# Altium schematic sheet Hillstar I2C-USB Bridge.SchDoc
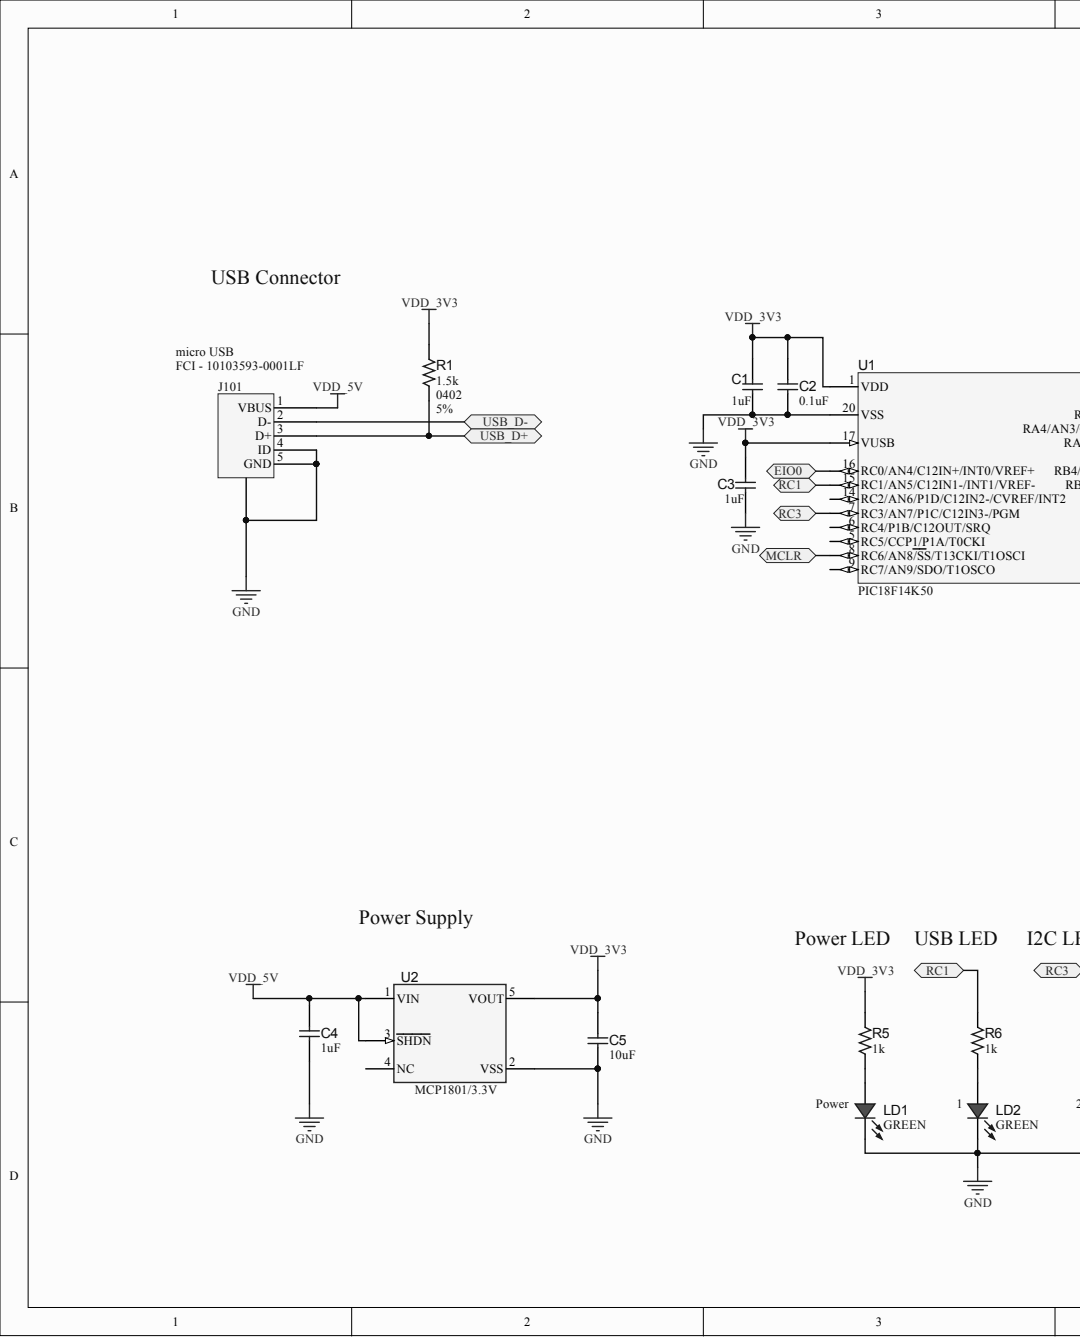
[diagram p0: Hillstar I2C-USB Bridge.SchDoc, left]
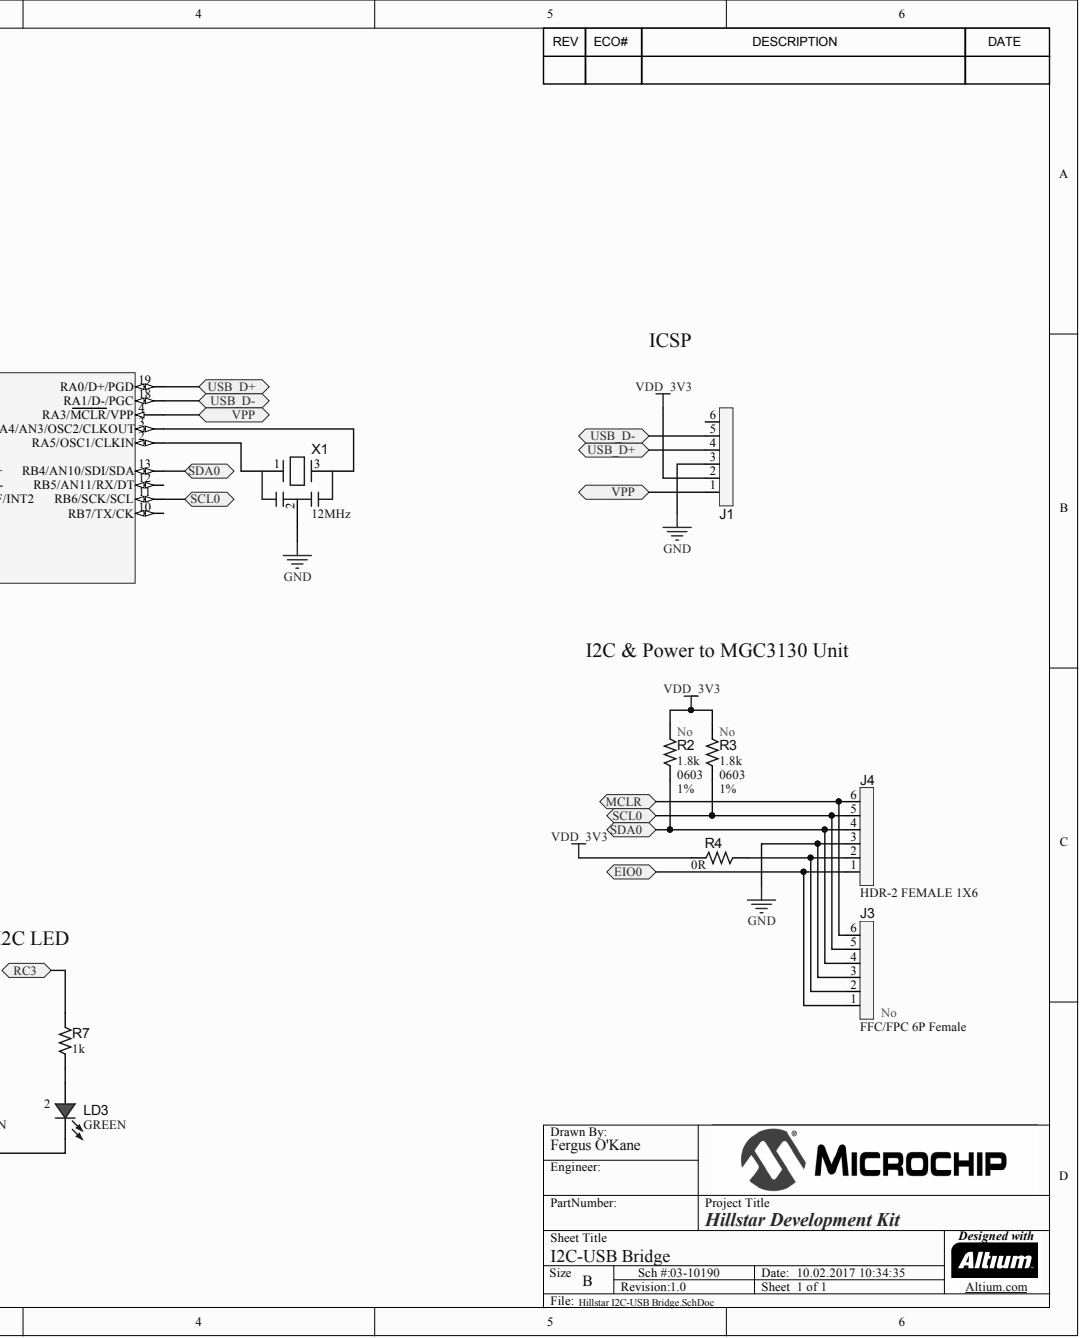
[diagram p1: Hillstar I2C-USB Bridge.SchDoc, right]

## Parts
C1  value 1uF  [CAP_C_0402]  (hidden comment: CAP CER 1uF 6.3V 10% X5R SMD 0402)
C2  value 0.1uF  [CAP_C_0402]  (hidden comment: CAP CER 0.1uF 10V 10% X5R SMD 0402)
C3  value 1uF  [CAP_C_0402]  (hidden comment: CAP CER 1uF 6.3V 10% X5R SMD 0402)
C4  value 1uF  [CAP_C_0402]  (hidden comment: CAP CER 1uF 6.3V 10% X5R SMD 0402)
C5  value 10uF  [CAP_C_0603]  (hidden comment: CAP CER 10uF 10V 20% X5R SMD 0603)
J1  Header 1x6  [HDR_M_1.27_1x6SMD]  (value not drawn)
J3  value FFC/FPC 6P Female  Populated: No  Type: FFC/FPC  [CON_FFC_1.0_FEMALE_1X6_SMD_52207]  (hidden comment: Header 1x6)
J4  value HDR-2 FEMALE 1X6  [HDR_F_2_1X6_SMD_RA]  (hidden comment: Header 1x6)
J101  micro USB  value FCI - 10103593-0001LF  Manufacturer 1: FCI  Manufacturer Part Number 1: 10103593-0001LF  [FCI - 10103593-0001LF]
LD1  value GREEN  [LED_0603_GREEN]  (hidden comment: LTST-C191KGKT)
LD2  value GREEN  [LED_0603_GREEN]  (hidden comment: LTST-C191KGKT)
LD3  value GREEN  [LED_0603_GREEN]  (hidden comment: LTST-C191KGKT)
R1  value 1.5k  Package: 0402  Tolerance: 5%  [RES_C_0402]  (hidden comment: RES TKF 1.5k 5% 1/16W SMD 0402)
R2  value 1.8k  Package: 0603  Populated: No  Tolerance: 1%  [RES_C_0603]  (hidden comment: RES TKF 1.8k 1% 1/10W SMD 0603)
R3  value 1.8k  Package: 0603  Populated: No  Tolerance: 1%  [RES_C_0603]  (hidden comment: RES TKF 1.8k 1% 1/10W SMD 0603)
R4  value 0R  [RES_C_0603]  (hidden comment: RES TKF 0R 1/10W SMD 0603)
R5  value 1k  [RES_C_0402]  (hidden comment: RES TKF 1k 5% 1/16W SMD 0402)
R6  value 1k  [RES_C_0402]  (hidden comment: RES TKF 1k 5% 1/16W SMD 0402)
R7  value 1k  [RES_C_0402]  (hidden comment: RES TKF 1k 5% 1/16W SMD 0402)
U1  value PIC18F14K50  [SSOP-20_P0.65]  (hidden comment: PIC18F14K50T-I/SS)
U2  value MCP1801/3.3V  [SOT-23-5]  (hidden comment: MCP1801 SOT-23-5)
X1  value 12MHz  [RESONATOR_CSTCE-G_L3.2W1.3H0.8]  (hidden comment: CSTCE12M0G55Z-R0)

## Ports
EIO0, MCLR, RC1, RC3, SCL0, SDA0, USB_D+, USB_D-, VPP

## Nets
GND: C1.1 C2.1 C3.1 C4.1 C5.1 J1.3 J3.3 J4.3 J101.4(ID) J101.5(GND) J101.GND LD1.2 LD2.2 LD3.2 U1.20(VSS) U2.2(VSS) X1.2
VDD_3V3: C1.2 C2.2 C3.2 C5.2 J1.2 R1.2 R2.2 R3.2 R4.1 R5.2 U1.1(VDD) U1.17(VUSB) U2.5(VOUT)
VDD_5V: C4.2 J101.1(VBUS) U2.1(VIN) U2.3(SHDN)
EIO0: J3.1 J4.1 U1.16(RC0/AN4/C12IN+/INT0/VREF+) ; port EIO0
MCLR: J3.6 J4.6 U1.8(RC6/AN8/SS/T13CKI/T1OSCI) ; port MCLR
RC1: R6.2 U1.15(RC1/AN5/C12IN1-/INT1/VREF-) ; port RC1
RC3: R7.2 U1.7(RC3/AN7/P1C/C12IN3-/PGM) ; port RC3
SCL0: J3.5 J4.5 R3.1 U1.11(RB6/SCK/SCL) ; port SCL0
SDA0: J3.4 J4.4 R2.1 U1.13(RB4/AN10/SDI/SDA) ; port SDA0
VPP: J1.1 U1.4(RA3/MCLR/VPP) ; port VPP
NetJ1_4: J1.4 J101.3(D+) R1.1 U1.19(RA0/D+/PGD)
NetJ1_5: J1.5 J101.2(D-) U1.18(RA1/D-/PGC)
NetJ3_2: J3.2 J4.2 R4.2
NetLD1_1: LD1.1 R5.1
NetLD2_1: LD2.1 R6.1
NetLD3_1: LD3.1 R7.1
NetU1_2: U1.2(RA5/OSC1/CLKIN) X1.1
NetU1_3: U1.3(RA4/AN3/OSC2/CLKOUT) X1.3

Not connected: J1 6; U1 5(RC5/CCP1/P1A/T0CKI) 6(RC4/P1B/C12OUT/SRQ) 9(RC7/AN9/SDO/T1OSCO) 10(RB7/TX/CK) 12(RB5/AN11/RX/DT) 14(RC2/AN6/P1D/C12IN2-/CVREF/INT2); U2 4(NC)

## Library data of the parts
C1 C3 C4 = CAP0616: Capacitor; Manufacturer 1: Murata; Manufacturer Part Number 1: GRM155R60J105KE19D; Populated: Yes; Type: Ceramic; Category: Capacitor; Component Kind: Standard; ComponentLink1Description: Datasheet; ComponentLink1URL: https://chn-vm-apps.microchip.com/mchprepo/parts/Datasheets/L0005E.pdf; Database Table Name: Capacitor; Description_: CAP CER 1uF 6.3V 10% X5R SMD 0402; Library Name: MCHP-CDB-Offline.DbLib; MCHP-ID: CAP0616; Operating Temperature: -55C to +85C; Package: 0402; Quantity Override: 1; Revision: 1; Status: Production; Supplier 1: Digi-Key; Supplier Part Number 1: 490-1320-1-ND; Temperature Coeffcient: X5R; Tolerance: 10%; Voltage: 6.3V
C2 = CAP0279: Capacitor; Manufacturer 1: KEMET; Manufacturer Part Number 1: C0402C104K8PACTU; Populated: Yes; Type: Ceramic; Category: Capacitor; Component Kind: Standard; ComponentLink1Description: Datasheet; ComponentLink1URL: https://chn-vm-apps.microchip.com/mchprepo/parts/Datasheets/KEM_C1002_X7R_SMD.pdf; Database Table Name: Capacitor; Description_: CAP CER 0.1uF 10V 10% X5R SMD 0402; Library Name: MCHP-CDB-Offline.DbLib; Manufacturer 2: Murata; Manufacturer 3: KEMET; Manufacturer Part Number 2: GRM155R61A104KA01D; Manufacturer Part Number 3: C0402C104K8PAC; MCHP-ID: CAP0279; Operating Temperature: -55C to +85C; Package: 0402; Quantity Override: 1; Revision: 1; Status: Production; Supplier 1: Digi-Key; Supplier 2: Digi-Key; Supplier 3: KEMET; Supplier Part Number 1: 399-3027-1-ND; Supplier Part Number 2: 490-1318-2-ND; Supplier Part Number 3: C0402C104K8PAC; Temperature Coeffcient: X5R; Tolerance: 10%; Voltage: 10V
C5 = CAP0542: Capacitor; Manufacturer 1: Panasonic; Manufacturer Part Number 1: ECJ-1VB1A106M; Populated: Yes; Type: Ceramic; Category: Capacitor; Component Kind: Standard; ComponentLink1Description: Datasheet; ComponentLink1URL: https://chn-vm-apps.microchip.com/mchprepo/parts/Datasheets/ECJ__General_.pdf; Database Table Name: Capacitor; Description_: CAP CER 10uF 10V 20% X5R SMD 0603; Library Name: MCHP-CDB-Offline.DbLib; Manufacturer 2: TDK Corporation; Manufacturer Part Number 2: C1608X5R1A106M; MCHP-ID: CAP0542; Operating Temperature: -55C to +85C; Package: 0603; Quantity Override: 1; Revision: 2; Status: Production; Supplier 1: Digi-Key; Supplier 2: Digi-Key; Supplier Part Number 1: PCC2479CT-ND; Supplier Part Number 2: 445-6853-1-ND; Temperature Coeffcient: X5R; Tolerance: 20%; Voltage: 10V
J1 = Header 1x6: Header 6-pin SMD; Component Kind: Standard; Library Name: Header6p_SMD_127.IntLib
J3 = CON1291: Manufacturer 1: Molex; Manufacturer Part Number 1: 52207-0633; Database Table Name: Connector; Description_: CON FFC/FPC 52207 1mm 6P Female SMD R/A; Owner: Tad Ahlvers; Category: Connector; Component Kind: Standard; ComponentLink1Description: Datasheet; ComponentLink1URL: https://chn-vm-apps.microchip.com/mchprepo/parts/Datasheets/0522070633_FFC_FPC_CONNECTORS-295382.pdf; Library Name: MCHP-CDB.DbLib; Manufacturer 2: Molex; Manufacturer Part Number 2: 0522070685; MCHP-ID: CON1291; Quantity Override: 1; Revision: B; Status: Design; Supplier 1: Mouser; Supplier 2: Digi-Key; Supplier Part Number 1: 538-52207-0633; Supplier Part Number 2: WM7676CT-ND
J4 = CON1205: Manufacturer 1: Fischer Elektronik; Manufacturer Part Number 1: BLY 6 SMD 6; Current: 2.5A; Database Table Name: Connector; Description_: CON HDR-2 Female 1x6 Tin SMD R/A; Owner: Jairuz Agang-ang; Populated: YES; Value: HDR-2 FEMALE 1X6; Voltage: 100 V DC; Category: Connector; Component Kind: Standard; ComponentLink1Description: Datasheet; ComponentLink1URL: https://chn-vm-apps.microchip.com/mchprepo/parts/Datasheets/735584-da-01-de-BUCHSENLEISTE__BLY_6_SMD__20.pdf; Library Name: MCHP-CDB-Offline.DbLib; MCHP-ID: CON1205; Quantity Override: 1; Revision: 1; Status: Production; Supplier 1: Fischer Elektronik; Supplier Part Number 1: BLY 6 SMD 6; Type: Header 2mm
J101 = micro USB: Description_: USB - micro B 2.0 5 Position Board Cutout; Populated: Yes; Component Kind: Standard; Library Name: micro_USB.SchLib; Supplier 1: Digi-Key; Supplier Part Number 1: 609-4049-1-ND
LD1-LD3 = DIODE1155: LED; Manufacturer 1: Lite-On Inc; Database Table Name: Diode; Description_: DIO LED GREEN 2V 30mA 35mcd Clear SMD 0603; Forward Current: 30mA; Owner: Tad Ahlvers; Populated: YES; Power: 75mW; Reverse Voltage: 5V; Type: LED Single; Category: Diode; Component Kind: Standard; ComponentLink1Description: Datasheet; ComponentLink1URL: https://chn-vm-apps.microchip.com/mchprepo/parts/Datasheets/S_110_LTST-C191KGKT.pdf; Library Name: MCHP-CDB.DbLib; Manufacturer Part Number 1: LTST-C191KGKT; MCHP-ID: DIODE1155; Operating Temperature: -55C to +85C; Quantity Override: 1; Revision: B; Status: Design; Supplier 1: Digi-Key; Supplier Part Number 1: 160-1446-1-ND
R1 = RES1493: Resistor; Manufacturer 1: Rohm Semiconductor; Manufacturer Part Number 1: MCR01MRTJ152; Owner: Mike Ragsdale; Populated: YES; Voltage: 50V; Category: Resistor; Component Kind: Standard; ComponentLink1Description: Datasheet; ComponentLink1URL: https://chn-vm-apps.microchip.com/mchprepo/parts/Datasheets/mcr_g.pdf; Composition: TKF; Database Table Name: Resistor; Description_: RES TKF 1.5k 5% 1/16W SMD 0402; Library Name: MCHP-CDB-Offline.DbLib; MCHP-ID: RES1493; Operating Temperature: -55C to +155C; Power: 1/16W; Quantity Override: 1; Revision: 1; Status: Design; Supplier 1: Digi-Key; Supplier Part Number 1: RHM1.5KCETR-ND; Type: RES SMD
R2 R3 = RES1110: Resistor; Manufacturer 1: Panasonic; Manufacturer Part Number 1: ERJ-3EKF1801V; Owner: Bogdan P (M91398); Temperature Coeffcient: 100ppm/C; Voltage: 75V; Category: Resistor; Component Kind: Standard; ComponentLink1Description: Datasheet; ComponentLink1URL: https://chn-vm-apps.microchip.com/mchprepo/parts/Datasheets/AOA0000CE2.pdf; Composition: TKF; Database Table Name: Resistor; Description_: RES TKF 1.8k 1% 1/10W SMD 0603; Library Name: MCHP-CDB-Offline.DbLib; MCHP-ID: RES1110; Operating Temperature: -55C to +155C; Power: 1/10W; Quantity Override: 1; Revision: 0; Status: Production; Supplier 1: Digi-Key; Supplier Part Number 1: P1.80KHCT-ND; Type: RES SMD
R4 = RSMT0001: Resistor; Manufacturer 1: NIC Components; Manufacturer Part Number 1: NRC06Z0TRF; Populated: Yes; Category: Resistor; Component Kind: Standard; ComponentLink1Description: Datasheet; ComponentLink1URL: https://chn-vm-apps.microchip.com/mchprepo/parts/Datasheets/nrc.pdf; Composition: TKF; Database Table Name: Resistor; Description_: RES TKF 0R 1/10W SMD 0603; Library Name: MCHP-CDB-Offline.DbLib; Manufacturer 2: Panasonic; Manufacturer 3: Panasonic; Manufacturer 4: Stackpole Electronics Inc; Manufacturer 5: Vishay; Manufacturer 6: Stackpole Electronics Inc; Manufacturer 7: Yageo; Manufacturer 8: KOA Speer; Manufacturer 9: ROHM; Manufacturer Part Number 2: ERJ-3GSY0R00V; Manufacturer Part Number 3: ERJ-3GEY0R00V; Manufacturer Part Number 4: RMCF0603ZT0R00; Manufacturer Part Number 5: CRCW06030000Z0EA; Manufacturer Part Number 6: RMCF 1/16 0 R; Manufacturer Part Number 7: RC0603JR-070RL; Manufacturer Part Number 8: RK73Z1JTTP; Manufacturer Part Number 9: MCR03EZPJ000; MCHP-ID: RSMT0001; Operating Temperature: -55C to +155C; Package: 0603; Power: 1/10W; Quantity Override: 1; Revision: 2; Status: Production; Supplier 1: NIC Components; Supplier 2: Digi-Key; Supplier 3: Digi-Key; Supplier 4: Digi-Key; Supplier 5: Digi-Key; Supplier 6: Digi-Key; Supplier 7: Digi-Key; Supplier 8: KOA Speer; Supplier 9: Digi-Key; Supplier Part Number 1: NRC06Z0TRF; Supplier Part Number 2: P0.0GTR-ND; Supplier Part Number 3: RMCF0603ZT0R00TR-ND; Supplier Part Number 4: RMCF0603ZT0R00CT-ND; Supplier Part Number 5: 541-0.0GCT-ND; Supplier Part Number 6: RMCF1/160RTR-ND; Supplier Part Number 7: 311-0.0GRCT-ND; Supplier Part Number 8: RK73Z1JTTP; Supplier Part Number 9: RHM0.0GCT-ND; Type: RES SMD
R5-R7 = RSMT0316: Resistor; Manufacturer 1: Panasonic; Manufacturer Part Number 1: ERJ-2GEJ102X; Owner: Tad Ahlvers; Populated: YES; Temperature Coeffcient: 200ppm/C; Voltage: 50V; Category: Resistor; Component Kind: Standard; ComponentLink1Description: Datasheet; ComponentLink1URL: https://chn-vm-apps.microchip.com/mchprepo/parts/Datasheets/AOA0000CE1.pdf; Composition: TKF; Database Table Name: Resistor; Description_: RES TKF 1k 5% 1/16W SMD 0402; Library Name: MCHP-CDB-Offline.DbLib; MCHP-ID: RSMT0316; Operating Temperature: -55C to +155C; Package: 0402; Power: 1/16W; Quantity Override: 1; Revision: 1; Status: Production; Supplier 1: Digi-Key; Supplier Part Number 1: P1.0KJCT-ND; Tolerance: 5%; Type: RES SMD
U1 = MIC0624: PIC18; Manufacturer 1: Microchip; ADCBit: 10; ADC Channels: 9; CAN: 0; Comparators: 2; Database Table Name: MCHP-Microcontroller; Description_: MCHP MCU 8-BIT 48MHz 16kB 768B PIC18F14K50T-I/SS SSOP-20; DigitalTimerQty_8bit: 1; DigitalTimerQty_16bit: 3; EEPROM: 256; ForceMinOrderQtyMult: True; IOPins: 15; LeadContent: Pb-FREE; LeadCount: 20; MAPS Description: 20 PIN, 16 KB FLASH, 768 RAM, 15 I/O, 10 BIT ADC, USB 2.0; MaxSpeed: 48; MinOrderQty: 1600; MPC: LEAG1TG3XB04; OscillatorMHz: 16 MHz, 32 kHz; PackageWidth: .209in; PackingMedia: T/R; Populated: Yes; Program Memory KBytes: 16; Program Memory KWords: 8; Ram: 768; Stage: REL; TempRange: -40C to +85C; CapTouchCH: 9; Category: MCHP-Microcontroller; Component Kind: Standard; ComponentLink1Description: Datasheet; ComponentLink1URL: http://www.microchip.com/wwwproducts/Devices.aspx?product=PIC18F14K50; DAChannels: 0; DigitalTimerQty_32bit: 0; Family: High Perf. 8-Bit; I2C: 1; Input Capture: 0; IntroductionDate: 2008-11-10; Library Name: MCHP-CDB-Offline.DbLib; Manufacturer 2: Microchip; Manufacturer Part Number 1: PIC18F14K50T-I/SS; Manufacturer Part Number 2: PIC18F14K50-I/SS; MCHP-ID: MIC0624; mtrlcntrlpwmchannels: 0; PackageType: SSOP; PWM Channels: 0; QEI Channels: 0; Quantity Override: 1; Revision: 1; SegmentLCD: 0; SMPS PWM Channels: 0; SPI: 1; Status: Production; Supplier 1: Microchip; Supplier 2: Microchip; Supplier Part Number 1: PIC18F14K50T-I/SS; Supplier Part Number 2: PIC18F14K50-I/SS; Type: 8-Bit; USART: 1; USBChannels: 1
U2 = MIC0804: LDO; Manufacturer 1: Microchip; Manufacturer Part Number 1: MCP1801T-3302I/OT; Accuracy: 2.0; Adjustable: No; Alarm_Mem: False; Comments: High PSRR (70 dB @ 10 kHz), Low Dropout, Low quiescent current (25uA); Database Table Name: MCHP-Analog; Description_: MCHP ANALOG LDO 3.3V MCP1801T-3302I/OT SOT-23-5; Dropoutvoltage: 250; LeadCount: 5; MAPS Description: High PSRR 150 mA LDO, Vin 10V max, Vout =0.9V; MaxInputVoltage: 10; MinInputVoltage: 2; MPC: YZ005TC7XA33; OutputCapType: CER/AL/TANT; OutputCurrent: 150; PackingMedia: T/R; Populated: Yes; SingleDual: Single; tblDeviceFamilyID: 1965; TempRange: -40C to +85C; TypicalActiveCurrent: 25; VoutMax: 6; VoutMin: 0.9; VOUTtyp: 0.9, 1.2, 1.8, 2.5, 3.0, 3.3, 5.0, 6.0; Brown_Out: False; Category: MCHP-Analog; Chamber_Test: False; Charge_Pump: False; Component Kind: Standard; ComponentLink1Description: Datasheet; ComponentLink1URL: http://www.microchip.com/wwwproducts/Devices.aspx?product=MCP1801; EncryptAlg: False; Inductive_Boost: False; InputChEnable: False; Interconnect: False; IntroductionDate: 2007-05-31; LED_Driver: False; LFTalkFeture: False; Library Name: MCHP-CDB-Offline.DbLib; Low_Bat: False; Low_Batt: False; ManualReset: False; MCHP-ID: MIC0804; PackageType: SOT-23; Power_Good: False; ProgWkUpFilter: False; Quantity Override: 1; RailIn: False; RailOut: False; ResetOutput: No; Rev_Batt_Prot: False; Revision: 1; Sens_Timer: False; SHDN: Yes; Status: Production; Supplier 1: Microchip; Supplier Part Number 1: MCP1801T-3302I/OT; Type: LDO; WatchdogTimer: False; WkUpPtnEnable: False
X1 = OSC0121: Resonator; Manufacturer 1: Murata; Database Table Name: Crystal-Oscillator; Description_: RESONATOR 12MHz 33pF SMD CSTCE-G; Load Capacitance: 33pF; Populated: YES; Tolerance: 0.5%; Category: Crystal/Oscillator; Component Kind: Standard; ComponentLink1Description: Datasheet; ComponentLink1URL: https://chn-vm-apps.microchip.com/mchprepo/parts/Datasheets/L0430E.pdf; Library Name: MCHP-CDB.DbLib; Manufacturer Part Number 1: CSTCE12M0G55Z-R0; MCHP-ID: OSC0121; Operating Temperature: -40C to +125C; Package: SMD_CSTCE-G; Quantity Override: 1; Revision: 1; Status: Design; Supplier 1: Digi-Key; Supplier Part Number 1: 490-1220-2-ND; Type: Resonator
